# Altium schematic sheet a0141b0_CSS Moonlight AS7265x_scm02.SchDoc
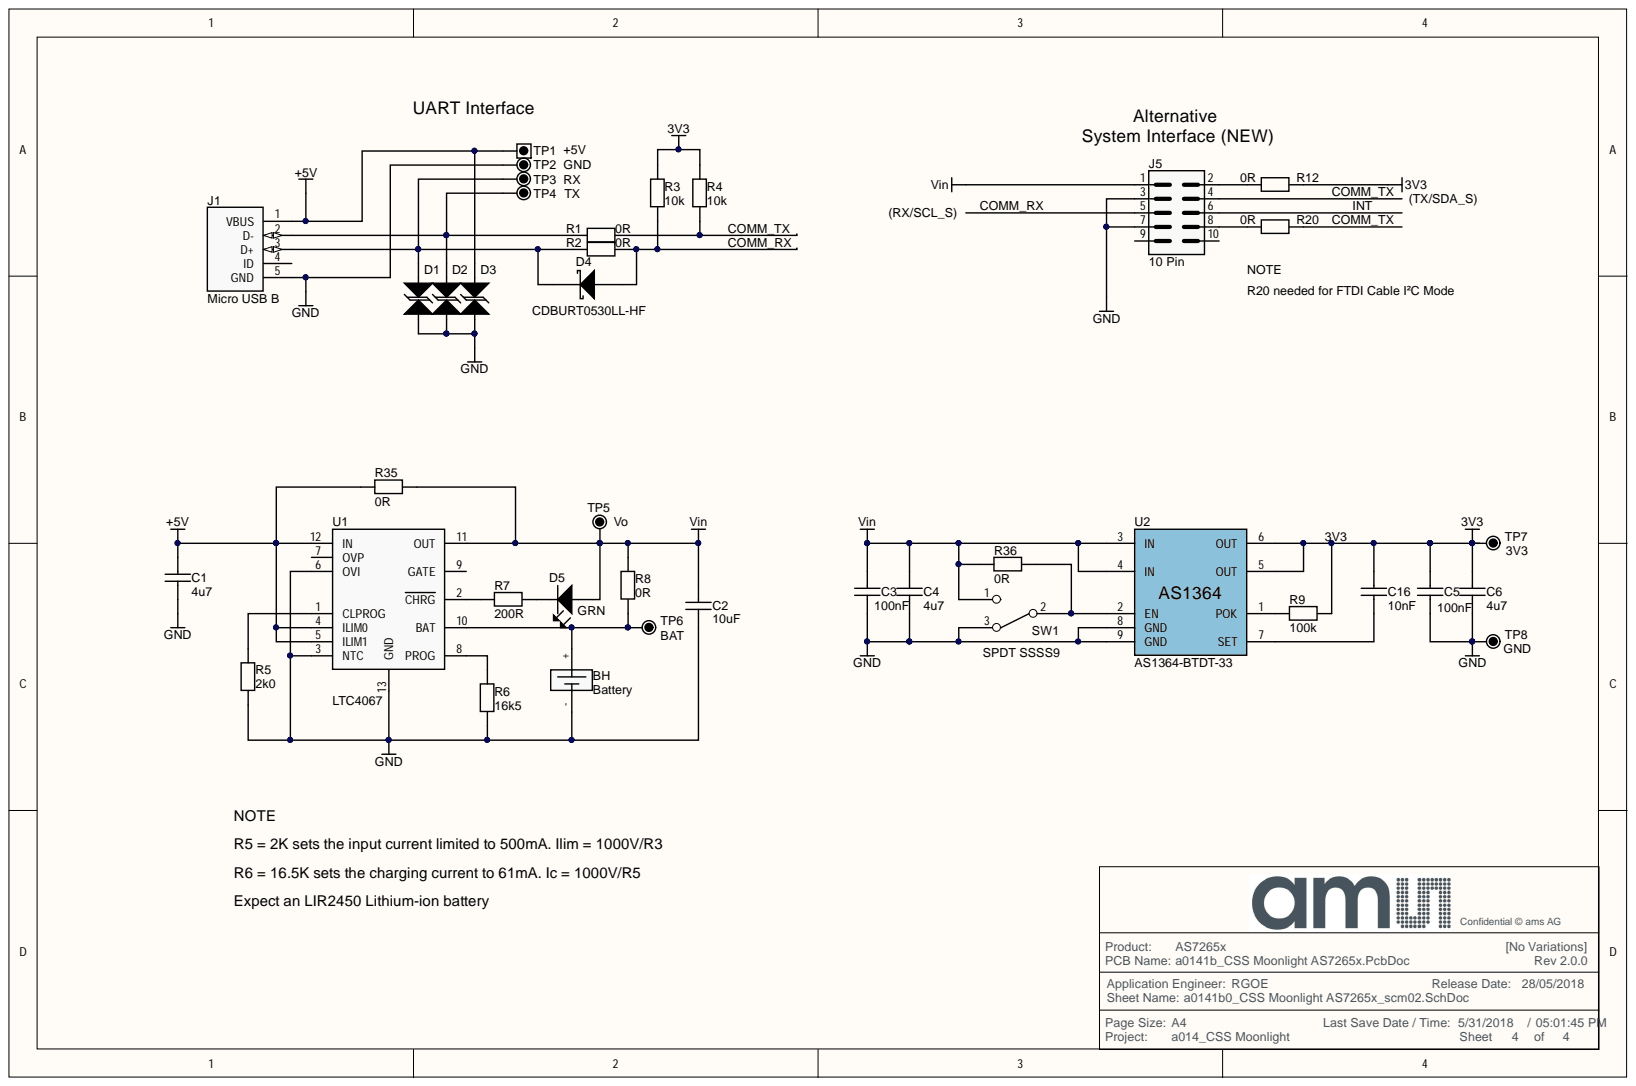
[diagram p0: a0141b0_CSS Moonlight AS7265x_scm02.SchDoc]

## Parts
BH  Battery  [BS-2450]
C1  4u7  [C0603]
C2  10uF  [C0603]
C3  100nF  [C0402]
C4  4u7  [C0402]
C5  100nF  [C0402]
C6  4u7  [C0402]
C16  10nF  [C0402]
D1  D_Suppressor_bip  [RW9_0402]  (value not drawn)
D2  D_Suppressor_bip  [RW9_0402]  (value not drawn)
D3  D_Suppressor_bip  [RW9_0402]  (value not drawn)
D4  CDBURT0530LL-HF  [SOD523F]
D5  GRN  [LED_osram_minitop_RG]
J1  Micro USB B  [Molex 47346-001 Bottom Mnt Micro B USB]
J5  10 Pin  [61201020621]
R1  0R  [R0402]
R2  0R  [R0402]
R3  10k  [R0402]
R4  10k  [R0402]
R5  2k0  [R0402]
R6  16k5  [R0402]
R7  200R  [R0402]
R8  0R  [R0402]
R9  100k  [R0402]
R12  0R  [R0402]
R20  0R  [R0402]
R35  0R  [R0402]
R36  0R  [R0402]
SW1  SPDT SSSS9  [SPDT_SSSS9]
TP1  +5V  [TP-30/44-S]  (no BOM)
TP2  GND  [TP-30/44-C]  (no BOM)
TP3  RX  [TP-30/44-C]  (no BOM)
TP4  TX  [TP-30/44-C]  (no BOM)
TP5  Vo  [TP-30/44-C]  (no BOM)
TP6  BAT  [TP-30/44-C]  (no BOM)
TP7  3V3  [TP-30/44-C]  (no BOM)
TP8  GND  [TP-30/44-C]  (no BOM)
U1  LTC4067  [4x3 DFN-12]
U2  AS1364-BTDT-33  [DFN-8]

## Nets
+5V: C1.1 D3.2 J1.1(VBUS) R35.1 TP1.1 U1.4(ILIM0) U1.5(ILIM1) U1.12(IN)
GND: BH.- C1.2 C2.2 C3.2 C4.2 C5.2 C6.2 D1.1 D2.1 D3.1 J1.5(GND) J5.3 J5.7 R5.1 R6.1 SW1.3 TP2.1 TP8.1 U1.3(NTC) U1.6(OVI) U1.13(GND) U2.8(GND) U2.9(GND)
Vin: C2.1 C3.1 C4.1 D5.2 J5.1 R8.2 R35.2 R36.1 SW1.1 TP5.1 U1.11(OUT) U2.3(IN) U2.4(IN)
3V3: C5.1 C6.1 C16.1 R3.2 R4.2 R9.2 R12.2 TP7.1 U2.5(OUT) U2.6(OUT)
COMM_RX: D4.A J5.5 R2.2 R3.1
COMM_TX: J5.4 R1.2 R4.1 R20.2
INT: J5.6
NetBH_+: BH.+ R8.1 TP6.1 U1.10(BAT)
NetC16_2: C16.2 U2.7(SET)
NetD1_2: D1.2 D4.K J1.3(D+) R2.1 TP3.1
NetD2_2: D2.2 J1.2(D-) R1.1 TP4.1
NetD5_1: D5.1 R7.1
NetJ5_2: J5.2 R12.1
NetJ5_8: J5.8 R20.1
NetR5_2: R5.2 U1.1(CLPROG)
NetR6_2: R6.2 U1.8(PROG)
NetR7_2: R7.2 U1.2(CHRG)
NetR9_1: R9.1 U2.1(POK)
NetR36_2: R36.2 SW1.2 U2.2(EN)

Not connected: J1 4(ID); J5 9 10; U1 7(OVP) 9(GATE)

## Library data of the parts
C1 = C_0603_4u7: Component Kind: Standard; Supplier 1: Digi-Key; Supplier Part Number 1: 445-5170-1-ND; Library Name: a0141a0_CSS Moonlight AS7265x.SCHLIB
C2 = C_0603_10uF: Component Kind: Standard; Supplier 1: Digi-Key; Supplier Part Number 1: 445-6853-1-ND; Library Name: a0141a0_CSS Moonlight AS7265x.SCHLIB
C3 C5 = C_0402_100nF: Component Kind: Standard; Supplier 1: Digi-Key; Supplier Part Number 1: 490-3261-1-ND; Library Name: a0141a0_CSS Moonlight AS7265x.SCHLIB
C4 C6 = C_0402_4u7: Component Kind: Standard; Supplier 1: Digi-Key; Supplier Part Number 1: 445-8023-1-ND; Library Name: a0141a0_CSS Moonlight AS7265x.SCHLIB
C16 = C_0402_10nF: Component Kind: Standard; Supplier 1: Digi-Key; Supplier Part Number 1: 490-17681-1-ND; Library Name: a0141b0_CSS Moonlight AS7265x.SCHLIB
D1-D3 = D_Suppressor_bip: PGB1010402KR; Component Kind: Standard; Supplier 1: Digi-Key; Supplier Part Number 1: F2862CT-ND; Library Name: a0141a0_CSS Moonlight AS7265x.SCHLIB
D4 = D Schottky: Schottky Diode; Code_JEDEC: SOD523F; Component Kind: Standard; LatestRevisionDate: 17-Jul-2002; LatestRevisionNote: Comchip; PackageDescription: SOD523F; Body 1.8 x 1.0 mm, leadless (LxW); PackageReference: 0603; Published: 8-Jun-2000; Signal Integrity: D Schottky; Simulation: SKYDIODE; Supplier 1: Digi-Key; Supplier Part Number 1: 641-1520-1-ND; Library Name: a0141a0_CSS Moonlight AS7265x.SCHLIB; Publisher: Altium Limited
D5 = LED_LG L29K-F2J1-24-Z  _1: Component Kind: Standard; Supplier 1: RSComponents; Supplier Part Number 1: 6544304; Library Name: a0141a0_CSS Moonlight AS7265x.SCHLIB
J1 = MicroUSB B: Component Kind: Standard; Supplier 1: Digi-Key; Supplier Part Number 1: WM17141CT-ND; Library Name: a0141a0_CSS Moonlight AS7265x.SCHLIB
J5 = (no symbol name): 10 Positions Header Connector 0.100" (2.54mm) Surface Mount Gold; Component Kind: Standard; Library Name: connectors_male.SchLib; Supplier 1: Digi-Key; Supplier Part Number 1: 732-5477-5-ND; Value: 10 Pin
R1 R2 R8 R12 R20 R35 R36 = R_0R: Component Kind: Standard; Supplier 1: Digi-Key; Supplier Part Number 1: 478-4709-1-ND; Library Name: a0141a0_CSS Moonlight AS7265x.SCHLIB
R3 R4 = R_10k: Component Kind: Standard; Supplier 1: Digi-Key; Supplier Part Number 1: P10KDECT-ND; Library Name: a0141a0_CSS Moonlight AS7265x.SCHLIB
R5 = R_2k0: Component Kind: Standard; Supplier 1: RSComponents; Supplier Part Number 1: 678-9065P; Library Name: a0141a0_CSS Moonlight AS7265x.SCHLIB
R6 = R_16k5: Component Kind: Standard; Supplier 1: Digi-Key; Supplier Part Number 1: YAG3002CT-ND; Library Name: a0141a0_CSS Moonlight AS7265x.SCHLIB
R7 = R_200R: Component Kind: Standard; Supplier 1: RSComponents; Supplier Part Number 1: 6788949; Library Name: a0141a0_CSS Moonlight AS7265x.SCHLIB
R9 = R_100k: Component Kind: Standard; Supplier 1: Digi-Key; Supplier Part Number 1: RG10P100KBCT-ND; Library Name: a0141a0_CSS Moonlight AS7265x.SCHLIB
TP1 = TP-30-S: Library Name: a0141a0_CSS Moonlight AS7265x.SCHLIB
TP2-TP8 = TP-30-C: Library Name: a0141a0_CSS Moonlight AS7265x.SCHLIB
U2 = AS1364: Component Kind: Standard; Supplier 1: Digi-Key; Supplier Part Number 1: AS1364-BTDT-33CT-ND; Library Name: a0141a0_CSS Moonlight AS7265x.SCHLIB
BH = Battery: Component Kind: Standard; Supplier 1: Digi-Key; Supplier Part Number 1: BS-2450-ND; Library Name: a0141a0_CSS Moonlight AS7265x.SCHLIB
SW1 = SPDT SSSS9: Component Kind: Standard; Supplier 1: RSComponents; Supplier Part Number 1: 1238955; Library Name: a0141a0_CSS Moonlight AS7265x.SCHLIB
U1 = LTC4067: Component Kind: Standard; Supplier 1: Digi-Key; Supplier Part Number 1: LTC4067EDE#TRPBFCT-ND; Library Name: a0141a0_CSS Moonlight AS7265x.SCHLIB
